# Altium schematic sheet stm32Core.SchDoc
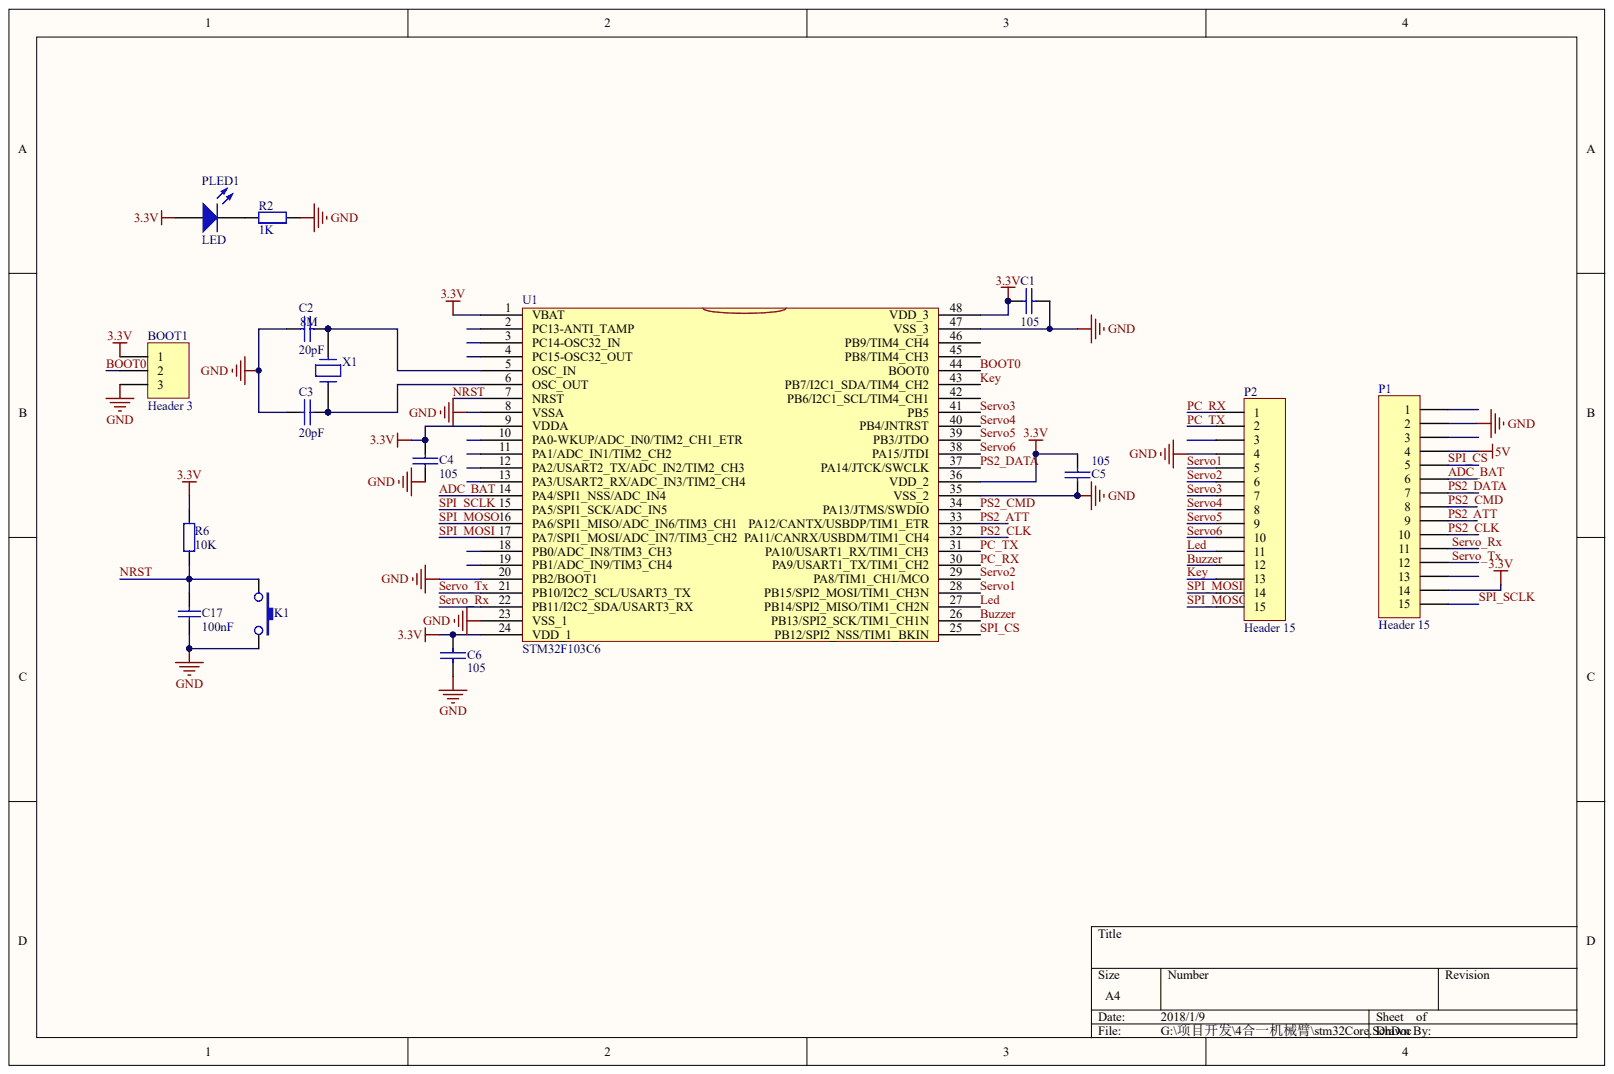
[diagram p0: stm32Core.SchDoc]

## Parts
BOOT1  Header 3
C1  105
C2  20pF
C3  20pF
C4  105
C5  105
C6  105
C17  value 100nF  (hidden comment: Cap)
K1  BUTTON  (value not drawn)
P1  Header 15
P2  Header 15
PLED1  LED
R2  value 1K  (hidden comment: Res2)
R6  value 10K  (hidden comment: Res1)
U1  STM32F103C6
X1  8M

## Nets
3.3V: BOOT1.1 C1.1 C4.2 C5.2 C6.2 P1.14 PLED1.1 R6.2 U1.1(VBAT) U1.9(VDDA) U1.24(VDD_1) U1.36(VDD_2) U1.48(VDD_3)
5V: P1.4
GND: BOOT1.3 C1.2 C2.2 C3.2 C4.1 C5.1 C6.1 C17.1 K1.2 P1.2 P2.4 R2.1 U1.8(VSSA) U1.20(PB2/BOOT1) U1.23(VSS_1) U1.35(VSS_2) U1.47(VSS_3)
ADC_BAT: P1.6 U1.14(PA4/SPI1_NSS/ADC_IN4)
BOOT0: BOOT1.2 U1.44(BOOT0)
BUZZER: P2.12 U1.26(PB13/SPI2_SCK/TIM1_CH1N)
KEY: P2.13 U1.43(PB7/I2C1_SDA/TIM4_CH2)
LED: P2.11 U1.27(PB14/SPI2_MISO/TIM1_CH2N)
NRST: C17.2 K1.1 R6.1 U1.7(NRST)
PC_RX: P2.1 U1.30(PA9/USART1_TX/TIM1_CH2)
PC_TX: P2.2 U1.31(PA10/USART1_RX/TIM1_CH3)
PS2_ATT: P1.9 U1.33(PA12/CANTX/USBDP/TIM1_ETR)
PS2_CLK: P1.10 U1.32(PA11/CANRX/USBDM/TIM1_CH4)
PS2_CMD: P1.8 U1.34(PA13/JTMS/SWDIO)
PS2_DATA: P1.7 U1.37(PA14/JTCK/SWCLK)
SERVO1: P2.5 U1.28(PB15/SPI2_MOSI/TIM1_CH3N)
SERVO2: P2.6 U1.29(PA8/TIM1_CH1/MCO)
SERVO3: P2.7 U1.41(PB5)
SERVO4: P2.8 U1.40(PB4/JNTRST)
SERVO5: P2.9 U1.39(PB3/JTDO)
SERVO6: P2.10 U1.38(PA15/JTDI)
SERVO_RX: P1.11 U1.22(PB11/I2C2_SDA/USART3_RX)
SERVO_TX: P1.12 U1.21(PB10/I2C2_SCL/USART3_TX)
SPI_CS: P1.5 U1.25(PB12/SPI2_NSS/TIM1_BKIN)
SPI_MOSI: P2.14 U1.17(PA7/SPI1_MOSI/ADC_IN7/TIM3_CH2)
SPI_MOSO: P2.15 U1.16(PA6/SPI1_MISO/ADC_IN6/TIM3_CH1)
SPI_SCLK: P1.15 U1.15(PA5/SPI1_SCK/ADC_IN5)
NetC2_1: C2.1 U1.5(OSC_IN) X1.2
NetC3_1: C3.1 U1.6(OSC_OUT) X1.1
NetPLED1_2: PLED1.2 R2.2

Not connected: P1 1 3 13; P2 3; U1 2(PC13-ANTI_TAMP) 3(PC14-OSC32_IN) 4(PC15-OSC32_OUT) 10(PA0-WKUP/ADC_IN0/TIM2_CH1_ETR) 11(PA1/ADC_IN1/TIM2_CH2) 12(PA2/USART2_TX/ADC_IN2/TIM2_CH3) 13(PA3/USART2_RX/ADC_IN3/TIM2_CH4) 18(PB0/ADC_IN8/TIM3_CH3) 19(PB1/ADC_IN9/TIM3_CH4) 42(PB6/I2C1_SCL/TIM4_CH1) 45(PB8/TIM4_CH3) 46(PB9/TIM4_CH4)

## Library data of the parts
BOOT1 C1-C6 C17 K1 P1 P2 PLED1 R2 R6 U1 X1 = (no symbol name)
  BOOT1 P1 P2: LatestRevisionDate: 17-Jul-2002; LatestRevisionNote: Re-released for DXP Platform.
  C17: Published: 8-Jun-2000; LatestRevisionDate: 17-Jul-2002; LatestRevisionNote: Re-released for DXP Platform.; PackageReference: RAD-0.3
  R2 R6: Published: 8-Jun-2000; LatestRevisionDate: 17-Jul-2002; LatestRevisionNote: Re-released for DXP Platform.; PackageReference: AXIAL-0.4
  BOOT1 C17 P1 P2 R2 R6: Publisher: Altium Limited
